# Altium schematic sheet Misc.SchDoc
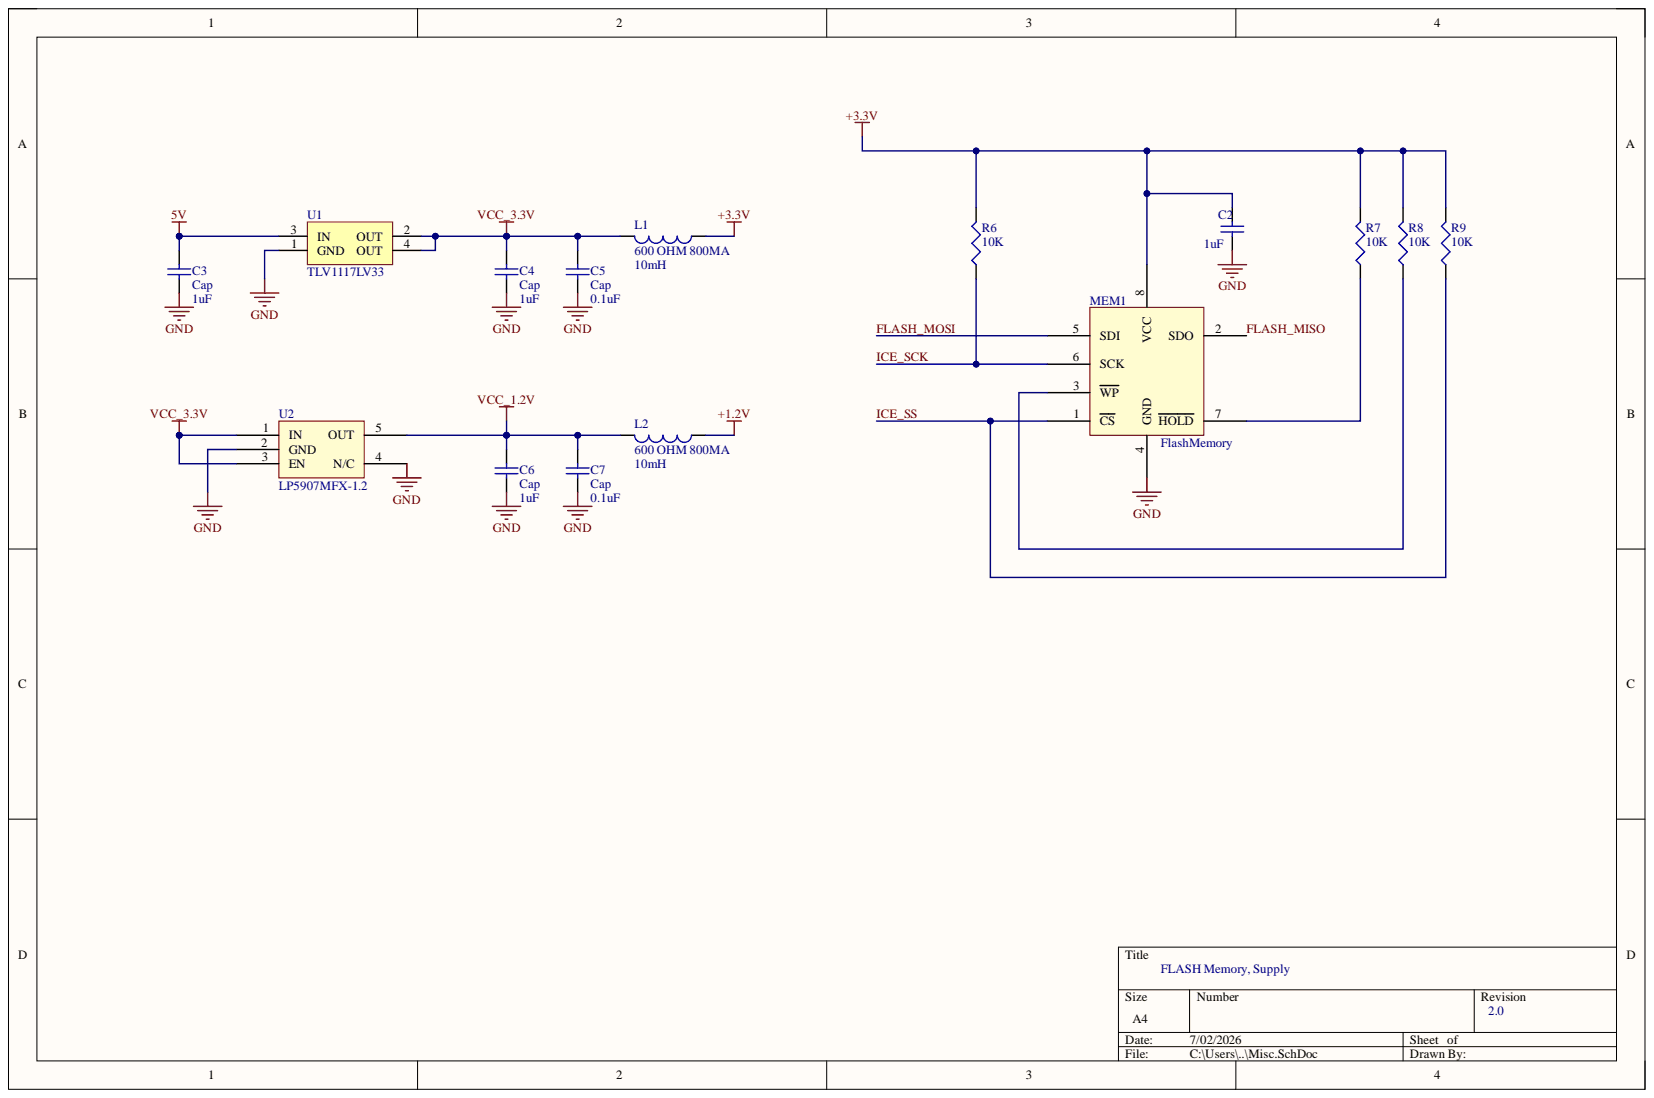
[diagram p0: Misc.SchDoc]

## Parts
C2  value 1uF  [C0402]  (hidden comment: Cap Semi)
C3  Cap  value 1uF  [C0603]
C4  Cap  value 1uF  [C0603]
C5  Cap  value 0.1uF  [C0402]
C6  Cap  value 1uF  [C0402]
C7  Cap  value 0.1uF  [C0402]
L1  600 OHM 800MA  value 10mH  [J1-0603]
L2  600 OHM 800MA  value 10mH  [J1-0603]
MEM1  FlashMemory  [SOP8FlashCorrect]
R6  value 10K  [R0402]  (hidden comment: Res3)
R7  value 10K  [R0402]  (hidden comment: Res3)
R8  value 10K  [R0402]  (hidden comment: Res3)
R9  value 10K  [R0402]  (hidden comment: Res3)
U1  TLV1117LV33  [ZZ311]
U2  LP5907MFX-1.2  [1-2Regulator]

## Nets
5V: C3.2 U1.3(IN)
+1.2V: L2.1
+3.3V: C2.2 L1.1 MEM1.8(VCC) R6.2 R7.2 R8.2 R9.2
GND: C2.1 C3.1 C4.1 C5.1 C6.1 C7.1 MEM1.4(GND) U1.1(GND) U2.2(GND) U2.4(N/C)
VCC_1.2V: C6.2 C7.2 L2.2 U2.5(OUT)
VCC_3.3V: C4.2 C5.2 L1.2 U1.2(OUT) U1.4(OUT) U2.1(IN) U2.3(EN)
FLASH_MISO: MEM1.2(SDO)
FLASH_MOSI: MEM1.5(SDI)
ICE_SCK: MEM1.6(SCK) R6.1
ICE_SS: MEM1.1(CS) R9.1
NetMEM1_3: MEM1.3(WP) R8.1
NetMEM1_7: MEM1.7(HOLD) R7.1

## Library data of the parts
C2 C6 = Cap Semi: Capacitor (Semiconductor SIM Model); Manufacturer: TDK Corporation; Manufacturer Part Number: CGB2A1JB1E105M033BC; Notification: Current market demand for these product types have resulted in fluctuating and extending lead times. Lead times may differ.; Temperature Coefficient: JB; Tolerance: ±20%; Voltage - Rated: 25V; Applications: General Purpose; Category: Capacitors; Component Kind: Standard; ComponentLink1Description: https://product.tdk.com/info/en/catalog/datasheets/mlcc_commercial_lowprofile_en.pdf; ComponentLink1URL: https://product.tdk.com/info/en/catalog/datasheets/mlcc_commercial_lowprofile_en.pdf; Failure Rate: -; Features: Low Profile; Height - Seated (Max): -; LatestRevisionDate: 29-May-2009; LatestRevisionNote: IPC-7351 Footprint Added.; Lead Spacing: -; Lead Style: -; Library Name: Miscellaneous Devices.IntLib; Mounting Type: Surface Mount, MLCC; Operating Temperature: -25°C ~ 85°C; Package / Case: 0402 (1005 Metric); PackageDescription: Chip Capacitor; PackageReference: C1206; Packaging: Cut Tape (CT); Part Status: Active; Pricing 1: 1=0.25, 10=0.173, 100=0.0874, 500=0.0598, 1000=0.0529, 2500=0.0506, 5000=0.046 (USD); Published: 8-Jun-2000; Ratings: -; RoHS: RoHS Compliant; Signal Integrity: Cap Semi; Simulation: CAP; Size / Dimension: 0.039" L x 0.020" W (1.00mm x 0.50mm); Stock 1: 0; Supplier 1: Digi-Key; Supplier Part Number 1: 445-12016-1-ND; Thickness (Max): 0.013" (0.33mm); Publisher: Altium Limited
C3 C4 = Cap Semi: Capacitor (Semiconductor SIM Model); Manufacturer: Yageo; Manufacturer Part Number: CC0603KRX5R8BB105; Notification: Current market demand for these product types have resulted in fluctuating and extending lead times. Lead times may differ.; Temperature Coefficient: X5R; Tolerance: ±10%; Voltage - Rated: 25V; Applications: General Purpose; Category: Capacitors; Component Kind: Standard; ComponentLink1Description: http://www.yageo.com/documents/recent/UPY-GPHC_X5R_4V-to-50V_25.pdf; ComponentLink1URL: http://www.yageo.com/documents/recent/UPY-GPHC_X5R_4V-to-50V_25.pdf; Failure Rate: -; Features: -; Height - Seated (Max): -; LatestRevisionDate: 29-May-2009; LatestRevisionNote: IPC-7351 Footprint Added.; Lead Spacing: -; Lead Style: -; Library Name: Miscellaneous Devices.IntLib; Mounting Type: Surface Mount, MLCC; Operating Temperature: -55°C ~ 85°C; Package / Case: 0603 (1608 Metric); PackageDescription: Chip Capacitor; PackageReference: C1206; Packaging: Cut Tape (CT); Part Status: Active; Pricing 1: 1=0.25, 10=0.179, 100=0.0843, 500=0.05908, 1000=0.04891 (USD); Published: 8-Jun-2000; Ratings: -; RoHS: RoHS Compliant; Signal Integrity: Cap Semi; Simulation: CAP; Size / Dimension: 0.063" L x 0.031" W (1.60mm x 0.80mm); Stock 1: 40000; Supplier 1: Digi-Key; Supplier Part Number 1: 311-1445-1-ND; Thickness (Max): 0.035" (0.90mm); Publisher: Altium Limited
C5 C7 = Cap Semi: Capacitor (Semiconductor SIM Model); Manufacturer: Samsung Electro-Mechanics; Manufacturer Part Number: CL05B104KA5NNNC; Notification: Current market demand for these product types have resulted in fluctuating and extending lead times. Lead times may differ.; Temperature Coefficient: X7R; Tolerance: ±10%; Voltage - Rated: 25V; Applications: General Purpose; Category: Capacitors; Component Kind: Standard; ComponentLink1Description: http://www.samsungsem.com/kr/support/product-search/mlcc/CL05B104KA5NNNC.jsp; ComponentLink1URL: http://www.samsungsem.com/kr/support/product-search/mlcc/CL05B104KA5NNNC.jsp; Features: -; Height - Seated (Max): -; LatestRevisionDate: 29-May-2009; LatestRevisionNote: IPC-7351 Footprint Added.; Lead Spacing: -; Lead Style: -; Library Name: Miscellaneous Devices.IntLib; Mounting Type: Surface Mount, MLCC; Operating Temperature: -55°C ~ 125°C; Package / Case: 0402 (1005 Metric); PackageDescription: Chip Capacitor; PackageReference: C1206; Packaging: Cut Tape (CT); Part Status: Active; Pricing 1: 1=0.1, 10=0.071, 100=0.0317, 500=0.02262, 1000=0.01777, 2500=0.01616, 5000=0.01486 (USD); Published: 8-Jun-2000; Ratings: -; RoHS: RoHS Compliant; Signal Integrity: Cap Semi; Simulation: CAP; Size / Dimension: 0.039" L x 0.020" W (1.00mm x 0.50mm); Stock 1: 0; Supplier 1: Digi-Key; Supplier Part Number 1: 1276-6720-1-ND; Thickness (Max): 0.022" (0.55mm); Publisher: Altium Limited
L1 L2 = Inductor: Manufacturer: Murata Electronics North America; Manufacturer Part Number: BLM18HE601SN1D; Code_IEC: 1005; DC Resistance (DCR) (Max): 250 mOhm; Filter Type: Signal Line; Category: Filters; Code_JEITA: 0402; Component Kind: Standard; ComponentLink1Description: https://www.murata.com/en-us/products/productdata/8796741599262/ENFA0004.pdf; ComponentLink1URL: https://www.murata.com/en-us/products/productdata/8796741599262/ENFA0004.pdf; Current Rating (Max): 800mA; Description: Inductor; Height (Max): 0.037" (0.95mm); LatestRevisionDate: 29-May-2009; LatestRevisionNote: IPC-7351 Footprint Added.; Library Name: Miscellaneous Devices.IntLib; Mounting Type: Surface Mount; Operating Temperature: -55°C ~ 125°C; Package / Case: 0603 (1608 Metric); PackageDescription: Chip Inductor; PackageReference: 0402-A; Packaging: Cut Tape (CT); Part Status: Active; Pricing 1: 1=0.22, 10=0.179, 25=0.1392, 50=0.1154, 100=0.0996, 250=0.07964, 500=0.07168, 1000=0.05973 (USD); Published: 8-Jun-2000; Ratings: -; RoHS: RoHS Compliant; Signal Integrity: Inductor; Simulation: INDUCTOR; Size / Dimension: 0.063" L x 0.032" W (1.60mm x 0.80mm); Stock 1: 152784; Supplier 1: Digi-Key; Supplier Part Number 1: 490-5214-1-ND; Publisher: Altium Limited
MEM1 = W25Q32JVSSIQ: IC FLASH 32M SPI 133MHZ 8SOIC; Manufacturer: Winbond Electronics; Memory Interface: SPI - Quad I/O; Memory Size: 32Mb (4M x 8); Memory Type: Non-Volatile; Voltage - Supply: 2.7 V ~ 3.6 V; Write Cycle Time - Word, Page: 3ms; Category: Integrated Circuits (ICs); Component Kind: Standard; ComponentLink1Description: http://www.winbond.com/resource-files/w25q32jv%20revg%2003272018%20plus.pdf; ComponentLink1URL: http://www.winbond.com/resource-files/w25q32jv%20revg%2003272018%20plus.pdf; Manufacturer Part Number: W25Q32JVSSIQ; Memory Format: FLASH; Mounting Type: Surface Mount; Operating Temperature: -40°C ~ 85°C (TA); Package / Case: 8-SOIC (0.209", 5.30mm Width); Packaging: Tube; Part Status: Active; Pricing 1: 1=0.81, 10=0.74, 25=0.7308, 90=0.72667, 180=0.64972, 270=0.64411, 540=0.63474, 810=0.62498, 1080=0.61183 (USD); RoHS: RoHS Compliant; Stock 1: 41181; Supplier 1: Digi-Key; Supplier Device Package: 8-SOIC; Supplier Part Number 1: W25Q32JVSSIQ-ND; Technology: FLASH - NOR; Library Name: FPGAlib.SchLib
R6-R9 = Res3: Resistor; Manufacturer: Yageo; Manufacturer Part Number: RC0402JR-0710KP; Power (Watts): 0.063W, 1/16W; Temperature Coefficient: ±150ppm/°C; Tolerance: ±5%; Category: Resistors; Component Kind: Standard; ComponentLink1Description: http://www.yageo.com/NewPortal/yageodocoutput?fileName=/pdf/R-Chip/PYu-RC_51_RoHS_P_0.pdf; ComponentLink1URL: http://www.yageo.com/NewPortal/yageodocoutput?fileName=/pdf/R-Chip/PYu-RC_51_RoHS_P_0.pdf; Composition: Thick Film; Failure Rate: -; Features: Moisture Resistant; Height - Seated (Max): 0.016" (0.40mm); LatestRevisionDate: 29-May-2009; LatestRevisionNote: IPC-7351 Footprint Added.; Library Name: Miscellaneous Devices.IntLib; Operating Temperature: -55°C ~ 155°C; Package / Case: 0402 (1005 Metric); PackageDescription: Chip Resistor; PackageReference: J1-0603; Packaging: Cut Tape (CT); Part Status: Active; Pricing 1: 1=0.1, 10=0.028, 100=0.0119, 1000=0.00557, 2500=0.0049, 5000=0.00411 (USD); Published: 8-Jun-2000; RoHS: RoHS Compliant; Signal Integrity: Res; Simulation: RESISTOR; Size / Dimension: 0.039" L x 0.020" W (1.00mm x 0.50mm); Stock 1: 0; Supplier 1: Digi-Key; Supplier Device Package: 0402; Supplier Part Number 1: YAG1281CT-ND; Publisher: Altium Limited
U1 = TLV1117LV33DCYR: IC REG LINEAR 3.3V 1A SOT223-4; Manufacturer: Texas Instruments; Output Configuration: Positive; Output Type: Fixed; Protection Features: Over Current, Over Temperature; PSRR: 75dB (120Hz); Voltage - Input (Max): 5.5V; Voltage Dropout (Max): 1.3V @ 800mA; Base Part Number: TLV1117LV33; Category: Integrated Circuits (ICs); Component Kind: Standard; ComponentLink1Description: http://www.ti.com/lit/ds/symlink/tlv1117lv.pdf; ComponentLink1URL: http://www.ti.com/lit/ds/symlink/tlv1117lv.pdf; Control Features: -; Current - Output: 1A; Manufacturer Part Number: TLV1117LV33DCYR; Mounting Type: Surface Mount; Operating Temperature: -40°C ~ 125°C; Package / Case: TO-261-4, TO-261AA; Packaging: Cut Tape (CT); Part Status: Active; Pricing 1: 1=0.68, 10=0.598, 100=0.4586, 500=0.36256, 1000=0.29005 (USD); RoHS: RoHS Compliant; Stock 1: 20855; Supplier 1: Digi-Key; Supplier Device Package: SOT-223-4; Supplier Part Number 1: 296-28778-1-ND; Voltage - Output (Max): -; Voltage - Output (Min/Fixed): 3.3V; Library Name: FPGAlib.SchLib
U2 = LP5907MFX-1.2/NOPB: IC REG LINEAR 1.2V 250MA SOT23-5; Manufacturer: Texas Instruments; Control Features: Enable; Output Configuration: Positive; Output Type: Fixed; Protection Features: Over Temperature, Short Circuit; PSRR: 90dB ~ 60dB (100Hz ~ 100kHz); Voltage - Input (Max): 5.5V; Voltage Dropout (Max): 0.25V @ 250mA; Category: Integrated Circuits (ICs); Component Kind: Standard; ComponentLink1Description: http://www.ti.com/lit/ds/symlink/lp5907.pdf; ComponentLink1URL: http://www.ti.com/lit/ds/symlink/lp5907.pdf; Current - Output: 250mA; Manufacturer Part Number: LP5907MFX-1.2/NOPB; Mounting Type: Surface Mount; Operating Temperature: -40°C ~ 125°C; Package / Case: SC-74A, SOT-753; Packaging: Cut Tape (CT); Part Status: Active; Pricing 1: 1=0.55, 10=0.475, 100=0.3547, 500=0.27872, 1000=0.21537 (USD); RoHS: RoHS Compliant; Stock 1: 65117; Supplier 1: Digi-Key; Supplier Device Package: SOT-23-5; Supplier Part Number 1: 296-39062-1-ND; Voltage - Output (Max): -; Voltage - Output (Min/Fixed): 1.2V; Library Name: FPGAlib.SchLib
